# Altium schematic sheet [06] - 3V3 VOLTAGE REGULATOR.SchDoc("[06] - 3V3 VOLTAGE REGULATOR")
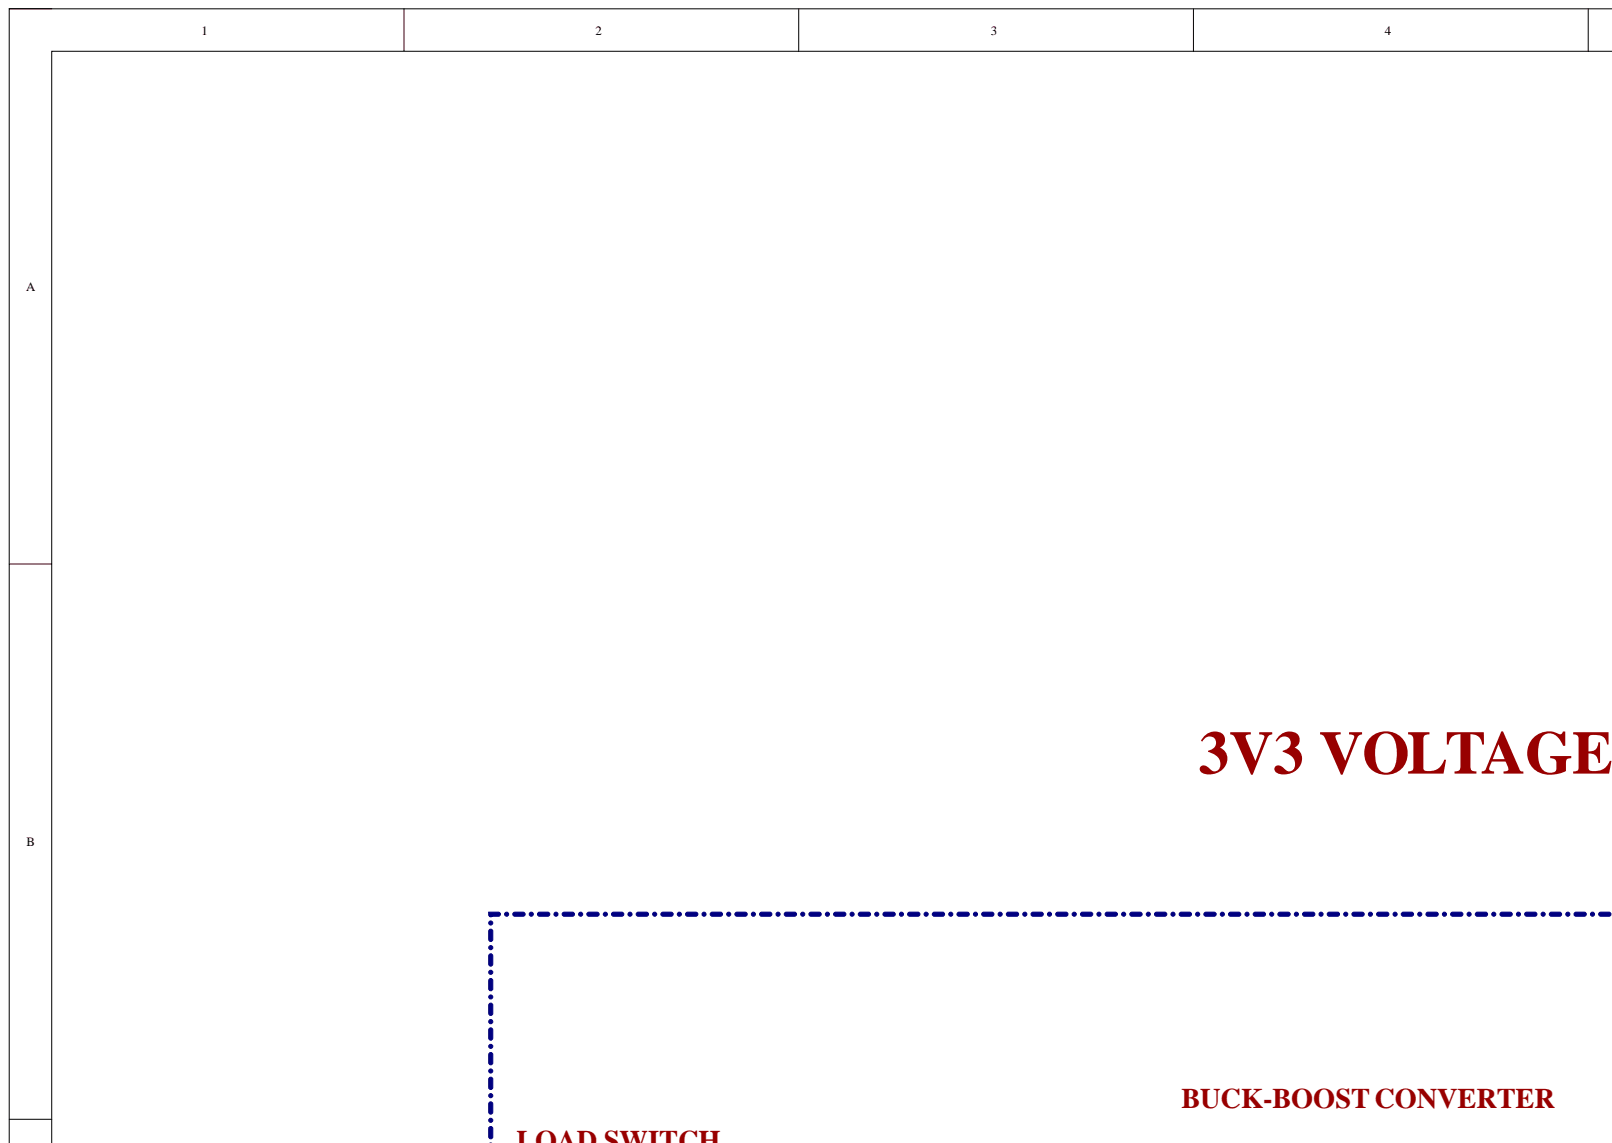
[diagram p0: [06] - 3V3 VOLTAGE REGULATOR.SchDoc, top left]
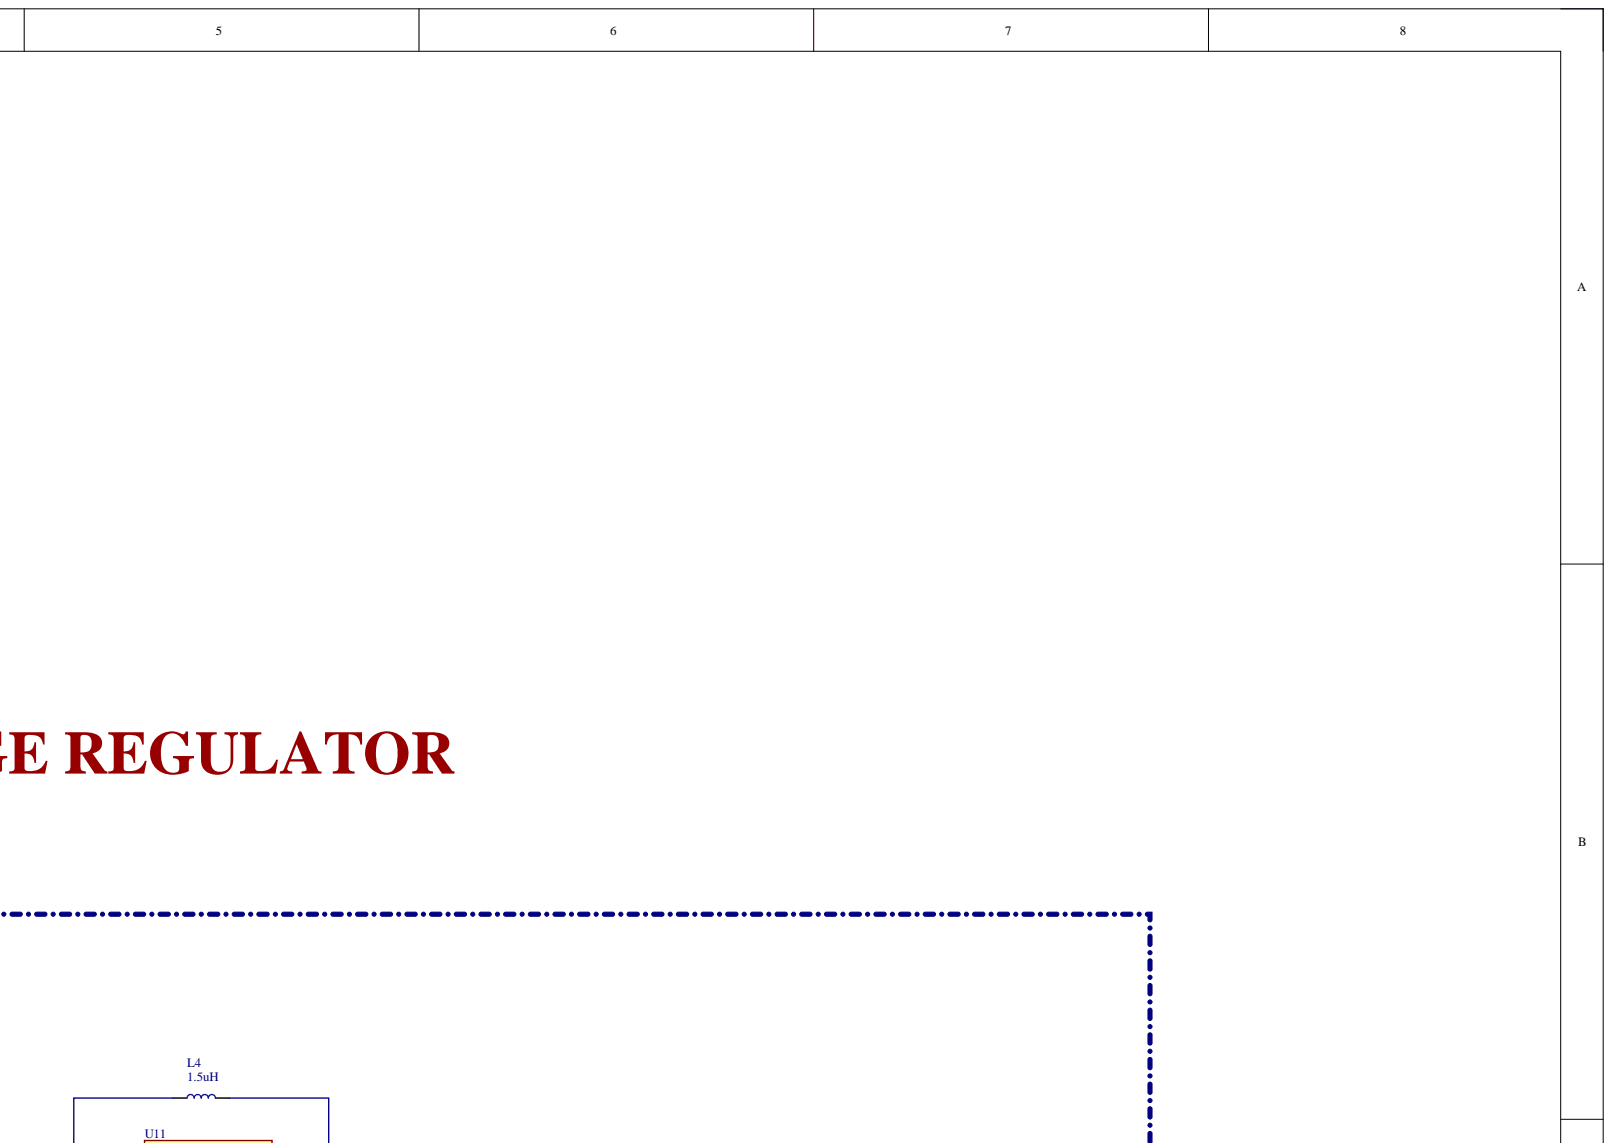
[diagram p1: [06] - 3V3 VOLTAGE REGULATOR.SchDoc, top right]
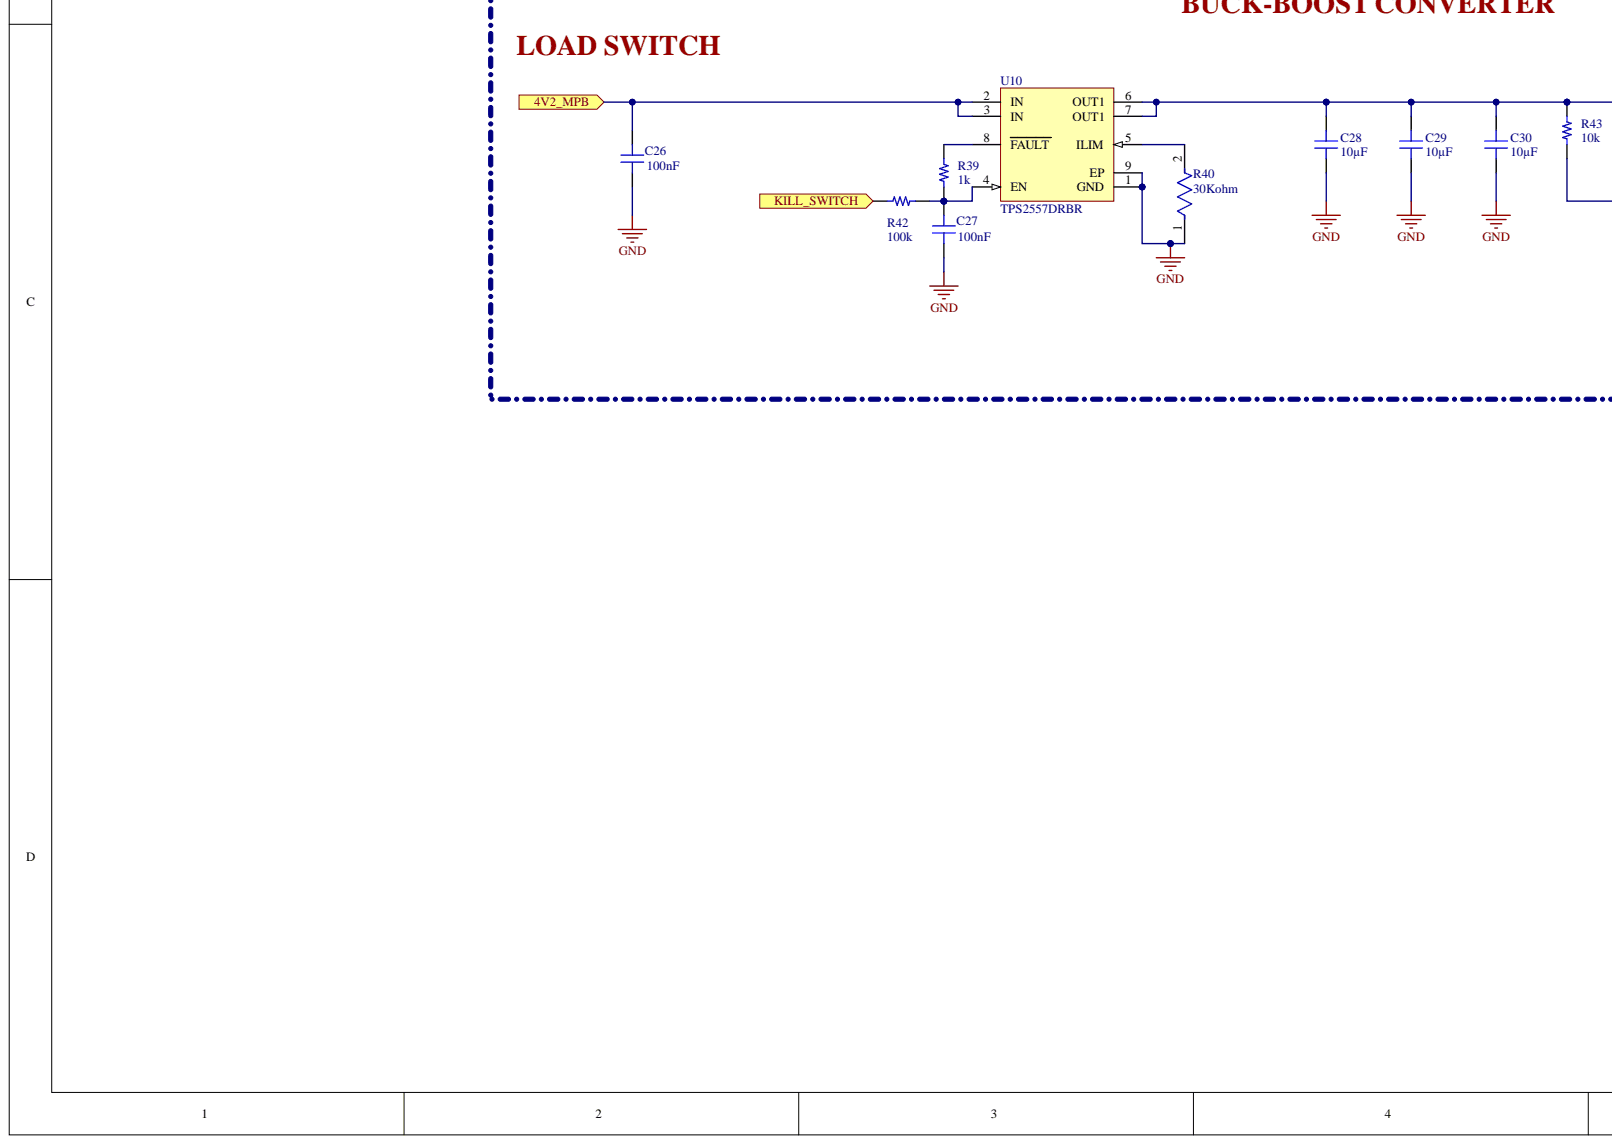
[diagram p2: [06] - 3V3 VOLTAGE REGULATOR.SchDoc, bottom left]
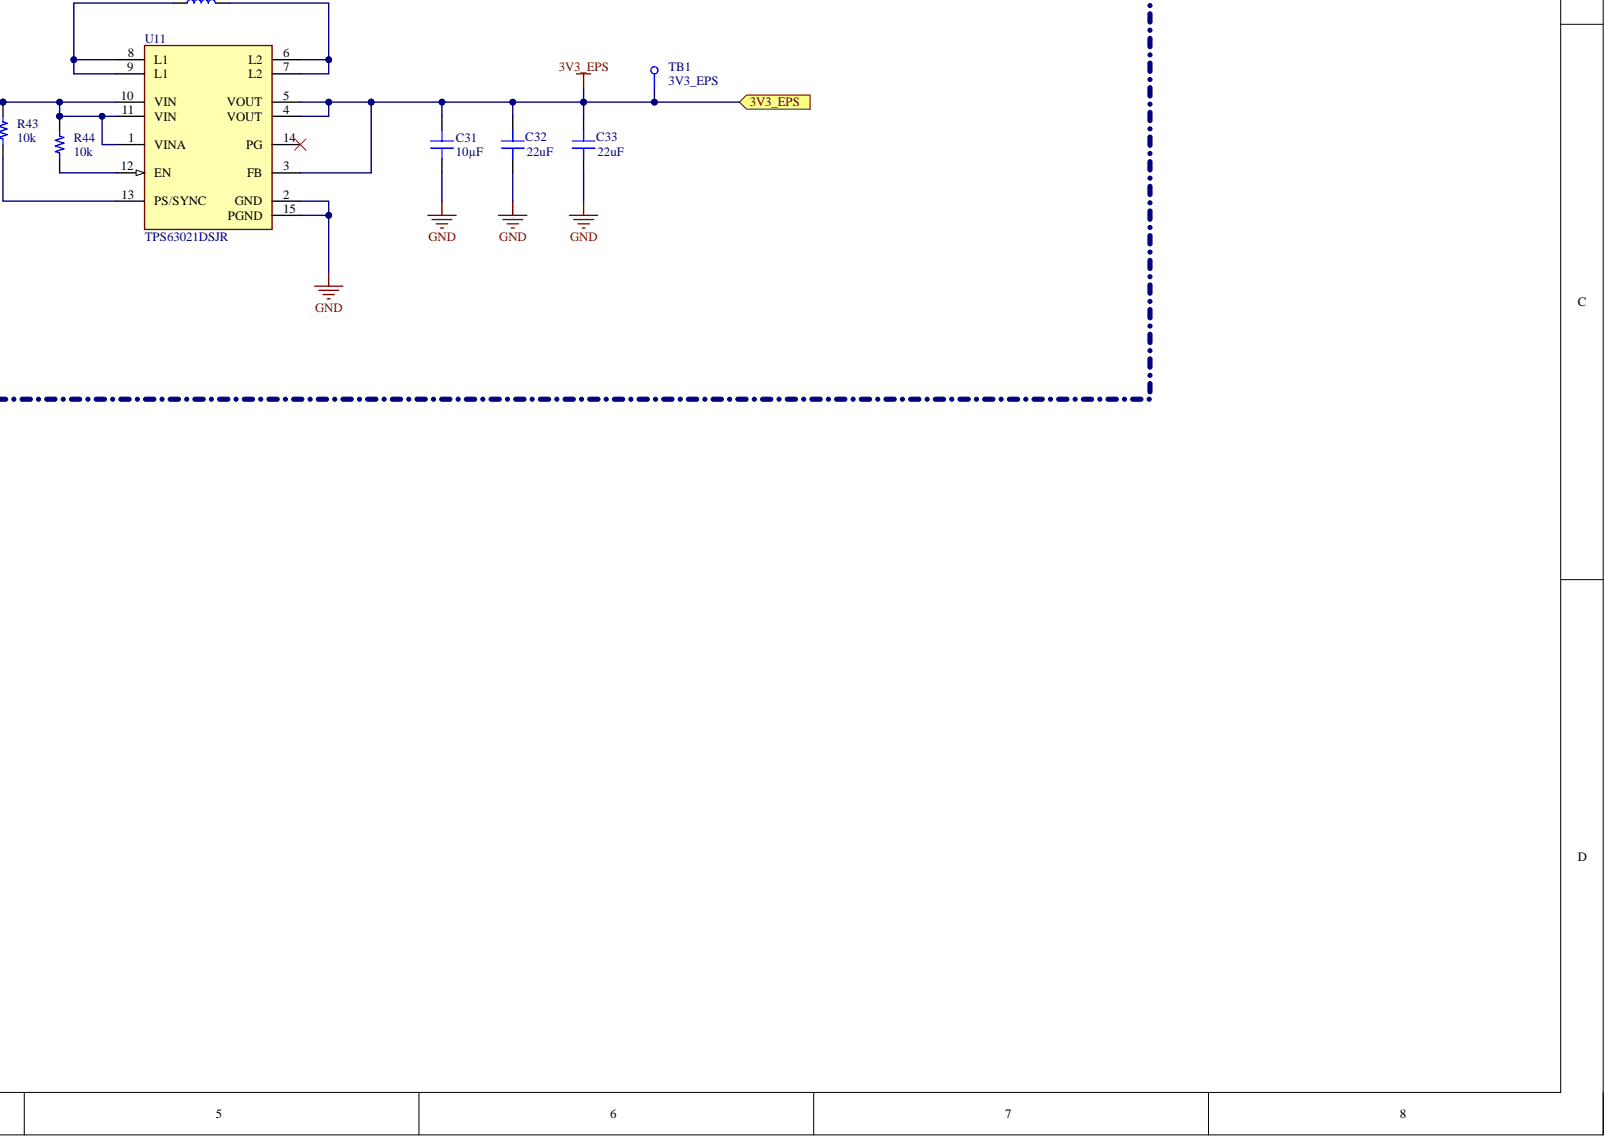
[diagram p3: [06] - 3V3 VOLTAGE REGULATOR.SchDoc, bottom right]

## Parts
C26  Capacitance: 100nF  [CAP 0603/1608]  (hidden comment: C0603C104K8RACTU)
C27  Capacitance: 100nF  [CAP 0603/1608]  (hidden comment: C0603C104K8RACTU)
C28  Capacitance: 10µF  [CAP 0805/2012]  (hidden comment: LMK212BJ106KG-T)
C29  Capacitance: 10µF  [CAP 0805/2012]  (hidden comment: LMK212BJ106KG-T)
C30  Capacitance: 10µF  [CAP 0603/1608]  (hidden comment: CL10A106MQ8NNNC)
C31  Capacitance: 10µF  [CAP 0603/1608]  (hidden comment: CL10A106MQ8NNNC)
C32  Capacitance: 22uF  [CAP 0805/2012]  (hidden comment: CL21A226MQQNNNE)
C33  Capacitance: 22uF  [CAP 0805/2012]  (hidden comment: CL21A226MQQNNNE)
L4  Inductance: 1.5uH  [XFL4020]  (hidden comment: XFL4020-152MEB)
R39  Resistance: 1k  [RES 0603/1608]  (hidden comment: ESR03EZPJ102)
R40  30Kohm  [FP-ESR03-IPC_C]
R42  Resistance: 100k  [RES 0603/1608]  (hidden comment: CR0603-JW-104ELF)
R43  Resistance: 10k  [RES 0805/2012]  (hidden comment: RC0805FR-0710KL)
R44  Resistance: 10k  [RES 0805/2012]  (hidden comment: RC0805FR-0710KL)
TB1  3V3_EPS  [Testpoint]
U10  TPS2557DRBR  [DRB0008B_V]
U11  TPS63021DSJR  [FP-DSJ0014A-MFG]

## Ports
3V3_EPS, 4V2_MPB, KILL_SWITCH

## Nets
GND: C26.1 C27.1 C28.1 C29.1 C30.1 C31.1 C32.1 C33.1 R40.1 U10.1(GND) U10.9(EP) U11.2(GND) U11.15(PGND)
3V3_EPS: C31.2 C32.2 C33.2 TB1.1 U11.3(FB) U11.4(VOUT) U11.5(VOUT) ; port 3V3_EPS
4V2_MPB: C26.2 U10.2(IN) U10.3(IN) ; port 4V2_MPB
KILL_SWITCH: R42.1 ; port KILL_SWITCH
NetC27_2: C27.2 R39.1 R42.2 U10.4(EN)
NetC28_2: C28.2 C29.2 C30.2 R43.2 R44.2 U10.6(OUT1) U10.7(OUT1) U11.1(VINA) U11.10(VIN) U11.11(VIN)
NetL4_1: L4.1 U11.8(L1) U11.9(L1)
NetL4_2: L4.2 U11.6(L2) U11.7(L2)
NetR39_2: R39.2 U10.8(FAULT)
NetR40_2: R40.2 U10.5(ILIM)
NetR43_1: R43.1 U11.13(PS/SYNC)
NetR44_1: R44.1 U11.12(EN)

Not connected: U11 14(PG)

## Library data of the parts
C26 C27 = C0603C104K8RACTU: CAP CER 0.1UF 10V X7R 0603; Manufacturer: KEMET; Dielectric Material: Ceramic; Dissipation Factor: 5%; Tolerance: 10%; Case Code (Imperial): 0603; Case Code (Metric): 1608; Case/Package: 0603; Component Kind: Standard; ComponentLink1Description: http://www.farnell.com/datasheets/2315110.pdf?src-supplier=Farnell; ComponentLink1URL: http://www.farnell.com/datasheets/2315110.pdf?src-supplier=Farnell; ComponentLink2Description: http://www.kemet.com/kemet/web/homepage/kechome.nsf/vapubfiles/KEM_C1002_X7R_SMD.pdf/$file/KEM_C1002_X7R_SMD.pdf?src-supplier=Element14; ComponentLink2URL: http://www.kemet.com/kemet/web/homepage/kechome.nsf/vapubfiles/KEM_C1002_X7R_SMD.pdf/$file/KEM_C1002_X7R_SMD.pdf?src-supplier=Element14; ComponentLink3Description: https://www.verical.com/server-webapp/html/getDatasheet?partID=91529&src-supplier=Verical; ComponentLink3URL: https://www.verical.com/server-webapp/html/getDatasheet?partID=91529&src-supplier=Verical; ComponentLink4Description: http://www.mouser.com/ds/2/212/KEM_C1002_X7R_SMD-1102033.pdf?src-supplier=Mouser; ComponentLink4URL: http://www.mouser.com/ds/2/212/KEM_C1002_X7R_SMD-1102033.pdf?src-supplier=Mouser; ComponentLink5Description: http://www.alliedelec.com/m/d/9bfe7773041ffe9d406e68bc7b377fdd.pdf?src-supplier=Allied+Electronics; ComponentLink5URL: http://www.alliedelec.com/m/d/9bfe7773041ffe9d406e68bc7b377fdd.pdf?src-supplier=Allied+Electronics; ComponentLink6Description: http://www.farnell.com/datasheets/1851736.pdf?src-supplier=Element14; ComponentLink6URL: http://www.farnell.com/datasheets/1851736.pdf?src-supplier=Element14; Contact Plating: Tin,Matte; Depth: 0.8mm; Dielectric: X7R; Height: 0.8mm; Lead Pitch: 0.7mm; Length: 1.6mm; Manufacturer Part Number: C0603C104K8RACTU; Max Operating Temperature: 125°C; Min Operating Temperature: -55°C; Mount: Surface Mount,PCB; Number of Pins: 2; Package Quantity: 1; Packaging: Tape and Reel; Pricing 1: 1=0.15, 10=0.107, 100=0.0504, 500=0.03536, 1000=0.02927 (USD); Radiation Hardening: No; REACH SVHC: No SVHC; Stock 1: 778651; Supplier 1: Digi-Key; Supplier Part Number 1: 399-1095-1-ND; Termination: SMD/SMT; Thickness: 0.034inch; Voltage: 10V; Voltage Rating: 10V; Voltage Rating (DC): 10V; Width: 0.8mm; Library Name: UVG.IntLib
C28 C29 = LMK212BJ106KG-T: CAP CER 10UF 10V X5R 0805; Manufacturer: Taiyo Yuden; PCN Part Number: X5R, X7R Dielectrics 23/Jan/2008; Catalog Drawings: xMK Series_0805_1.25; Featured Product: Multilayer Chip Capacitors; Kits: 587-1797-KIT-ND  - KIT CAP CER HI CAP 0603 & 0805 S; Online Catalog: M Series; Other Names: 587-1300-1; Tolerance: ±10%; Applications: General Purpose; Category: Capacitors; Component Kind: Standard; ComponentLink1Description: https://datasheet.ciiva.com/26872/getdatasheetpartid-638410-26872692.pdf; ComponentLink1URL: https://datasheet.ciiva.com/26872/getdatasheetpartid-638410-26872692.pdf; ComponentLink2Description: https://datasheet.ciiva.com/7975/1497285-7975797.pdf; ComponentLink2URL: https://datasheet.ciiva.com/7975/1497285-7975797.pdf; ComponentLink3Description: https://datasheet.ciiva.com/7975/1497285-7975799.pdf; ComponentLink3URL: https://datasheet.ciiva.com/7975/1497285-7975799.pdf; ComponentLink4Description: https://datasheet.ciiva.com/135/mlcc-all-e-135133.pdf; ComponentLink4URL: https://datasheet.ciiva.com/135/mlcc-all-e-135133.pdf; ComponentLink5Description: https://datasheet.ciiva.com/135/mlcc-all-e-135134.pdf; ComponentLink5URL: https://datasheet.ciiva.com/135/mlcc-all-e-135134.pdf; ComponentLink6Description: https://datasheet.ciiva.com/30259/719lmk212abj106kg-t-30259022.pdf; ComponentLink6URL: https://datasheet.ciiva.com/30259/719lmk212abj106kg-t-30259022.pdf; ComponentLink7Description: https://datasheet.ciiva.com/135/mlcc-all-e-135135.pdf; ComponentLink7URL: https://datasheet.ciiva.com/135/mlcc-all-e-135135.pdf; Family: Ceramic Capacitors; Features: -; Height - Seated (Max): -; Lead Spacing: -; Lead Style: -; Manufacturer Part Number: LMK212BJ106KG-T; Mounting Type: Surface Mount, MLCC; Operating Temperature: -55°C ~ 85°C; Package / Case: 0805 (2012 Metric); Packaging: Cut Tape (CT); Pricing 1: 1=0.14, 10=0.1, 100=0.0468, 500=0.0328, 1000=0.0272 (USD); Ratings: -; Series: M; Size / Dimension: 0.079" L x 0.049" W (2.00mm x 1.25mm); Standard Package: 1; Stock 1: 858762; Supplier 1: Digi-Key; Supplier Part Number 1: 587-1300-1-ND; Temperature Coefficient: X5R; Thickness (Max): 0.053" (1.35mm); Voltage - Rated: 10V; Library Name: UVG.IntLib
C30 C31 = CL10A106MQ8NNNC: CAP CER 10UF 6.3V X5R 0603; Manufacturer: Samsung; Tolerance: 20%; Case Code (Imperial): 0603; Case Code (Metric): 1608; Case/Package: 0603; Component Kind: Standard; ComponentLink1Description: https://datasheet.ciiva.com/25798/cl10a106mq8nnnc-25798320.pdf?src-supplier=Digi-Key; ComponentLink1URL: https://datasheet.ciiva.com/25798/cl10a106mq8nnnc-25798320.pdf?src-supplier=Digi-Key; ComponentLink2Description: https://datasheet.ciiva.com/26838/getdatasheetpartid-357557-26838347.pdf?src-supplier=Verical; ComponentLink2URL: https://datasheet.ciiva.com/26838/getdatasheetpartid-357557-26838347.pdf?src-supplier=Verical; ComponentLink3Description: https://datasheet.ciiva.com/16437/cl05b104kq5nnnc-16437327.pdf?src-supplier=Future+Electronics; ComponentLink3URL: https://datasheet.ciiva.com/16437/cl05b104kq5nnnc-16437327.pdf?src-supplier=Future+Electronics; Contact Plating: Tin; Depth: 0.8mm; Dielectric: X5R; Height: 0.8mm; Length: 1.6mm; Manufacturer Part Number: CL10A106MQ8NNNC; Max Operating Temperature: 85°C; Min Operating Temperature: -55°C; Mount: Surface Mount; Package Quantity: 1; Packaging: Tape and Reel; Pricing 1: 1 = 0.15000, 10 = 0.11000, 100 = 0.05150, 500 = 0.03614, 1000 = 0.02992 (USD); Radiation Hardening: No; RoHS: Yes; Stock 1: 3866590; Supplier 1: Digi-Key; Supplier Part Number 1: 1276-1119-1-ND; Thickness: 0.035inch; Voltage: 6.3V; Voltage Rating: 6.3V; Width: 0.031inch; Library Name: UVG.IntLib
C32 C33 = CL21A226MQQNNNE: CAP CER 22UF 6.3V X5R 0805; Manufacturer: Samsung; Tolerance: 20%; Case Code (Imperial): 0805; Case Code (Metric): 2012; Case/Package: 0805; Component Kind: Standard; ComponentLink1Description: https://datasheet.ciiva.com/25796/cl21a226mqqnnne-25796195.pdf; ComponentLink1URL: https://datasheet.ciiva.com/25796/cl21a226mqqnnne-25796195.pdf; ComponentLink2Description: https://datasheet.ciiva.com/26838/getdatasheetpartid-357607-26838611.pdf; ComponentLink2URL: https://datasheet.ciiva.com/26838/getdatasheetpartid-357607-26838611.pdf; ComponentLink3Description: https://datasheet.ciiva.com/16361/cl21a226mqqnnne-16361189.pdf; ComponentLink3URL: https://datasheet.ciiva.com/16361/cl21a226mqqnnne-16361189.pdf; ComponentLink4Description: https://datasheet.ciiva.com/26787/cl21a226mqqnnne-26787006.pdf; ComponentLink4URL: https://datasheet.ciiva.com/26787/cl21a226mqqnnne-26787006.pdf; Contact Plating: Tin; Depth: 1.25mm; Dielectric: X5R; Height: 1.25mm; Lead Free: Lead Free; Length: 2mm; Manufacturer Part Number: CL21A226MQQNNNE; Max Operating Temperature: 85°C; Min Operating Temperature: -55°C; Mount: Surface Mount; Package Quantity: 2000; Packaging: Tape and Reel; Pricing 1: 1=0.22, 10=0.152, 100=0.0768, 500=0.05256, 1000=0.04649 (USD); Radiation Hardening: No; Stock 1: 20000; Supplier 1: Digi-Key; Supplier Part Number 1: 1276-1100-6-ND; Thickness: 0.055inch; Voltage Rating: 6.3V; Voltage Rating (DC): 6.3V; Width: 0.049inch; Library Name: UVG.IntLib
L4 = XFL4020-152MEB: FIXED IND 1.5UH 9.1A 15.8 MOHM SMD; Manufacturer: Coilcraft; Core Material: Composite; DC Resistance (DCR): 15.8mR; Self Resonant Frequency: 59MHz; Shielding: Shielded; Test Frequency: 100kHz; Tolerance: 20%; Component Kind: Standard; ComponentLink1Description: http://www.mouser.com/ds/2/597/xfl4020-270756.pdf?src-supplier=Mouser; ComponentLink1URL: http://www.mouser.com/ds/2/597/xfl4020-270756.pdf?src-supplier=Mouser; Height: 2.1mm; Lead Free: Contains Lead; Length: 4.3mm; Manufacturer Part Number: XFL4020-152MEB; Max DC Current: 9.1A; Max Operating Temperature: 125°C; Min Operating Temperature: -40°C; Package Quantity: 25; Packaging: Tape and Reel; Pricing 1: 1 = 1.99000, 10 = 1.99000, 50 = 1.99000, 100 = 1.59000, 1000 = 0.88500, 10000 = 0.88500 (USD); RoHS: Yes; Stock 1: 11555; Supplier 1: Mouser; Supplier Part Number 1: 994-XFL4020-152MEB; Termination: SMD/SMT; Width: 4.3mm; Library Name: UVG.IntLib
R39 = ESR03EZPJ102: RES SMD 1K OHM 5% 1/4W 0603; Manufacturer: Rohm; Max Power Dissipation: 250mW; Temperature Coefficient: 200ppm/°C; Case Code (Imperial): 0603; Case Code (Metric): 1608; Case/Package: 0603; Component Kind: Standard; ComponentLink1Description: https://datasheet.ciiva.com/2469/esr-2469603.pdf?src-supplier=Digi-Key; ComponentLink1URL: https://datasheet.ciiva.com/2469/esr-2469603.pdf?src-supplier=Digi-Key; ComponentLink2Description: https://datasheet.ciiva.com/2469/esr-2469604.pdf?src-supplier=Digi-Key; ComponentLink2URL: https://datasheet.ciiva.com/2469/esr-2469604.pdf?src-supplier=Digi-Key; ComponentLink3Description: https://datasheet.ciiva.com/2469/esr-2469605.pdf?src-supplier=Digi-Key; ComponentLink3URL: https://datasheet.ciiva.com/2469/esr-2469605.pdf?src-supplier=Digi-Key; Composition: Thick Film; Features: Automotive AEC-Q200; Height: 0.022inch; Lead Free: Lead Free; Length: 0.063inch; Manufacturer Part Number: ESR03EZPJ102; Max Operating Temperature: 155°C; Min Operating Temperature: -55°C; Number of Terminations: 2; Package Quantity: 1; Packaging: Tape and Reel; Pricing 1: 1 = 0.10000, 10 = 0.08300, 100 = 0.03180, 1000 = 0.01398, 2500 = 0.01207 (USD); RoHS: Yes; Stock 1: 187581; Supplier 1: Digi-Key; Supplier Part Number 1: RHM1.0KDCT-ND; Tolerance: 5%; Width: 0.031inch; Library Name: UVG.IntLib
R40 = CMP-08839-000999-1: 30kΩ ±1% 0.25W 0603 Anti-Surge Chip Resistor AEC-Q200; Max Power Dissipation: 250mW; Resistance: 30kR; Temperature Coefficient: 100ppm/°C; Case/Package: 0603; Component Kind: Standard; Features: Automotive AEC-Q200; Library Name: Altium Content Vault; Max Operating Temperature: 155°C; Min Operating Temperature: -55°C; Number of Terminations: 2; Packaging: Tape and Reel; Resistor Type: Thick Film; RoHS Compliant: Yes; Series: ESR; Tolerance: 1%
R42 = CR0603-JW-104ELF: RES SMD 100K OHM 5% 1/10W 0603; Manufacturer: Bourns; Power Rating: 100mW; Temperature Coefficient: 200ppm/°C; Voltage Rating: 50V; Case Code (Imperial): 0603; Case Code (Metric): 1608; Case/Package: 0603; Component Kind: Standard; ComponentLink1Description: https://datasheet.ciiva.com/22713/691949-22713239.pdf?src-supplier=Element14; ComponentLink1URL: https://datasheet.ciiva.com/22713/691949-22713239.pdf?src-supplier=Element14; ComponentLink2Description: https://datasheet.ciiva.com/23776/1902866-23776391.pdf?src-supplier=Newark; ComponentLink2URL: https://datasheet.ciiva.com/23776/1902866-23776391.pdf?src-supplier=Newark; ComponentLink3Description: https://datasheet.ciiva.com/16766/70154685-16766760.pdf?src-supplier=Allied+Electronics; ComponentLink3URL: https://datasheet.ciiva.com/16766/70154685-16766760.pdf?src-supplier=Allied+Electronics; ComponentLink4Description: https://datasheet.ciiva.com/30229/159chpreztr-30229129.pdf?src-supplier=Onlinecomponents.com; ComponentLink4URL: https://datasheet.ciiva.com/30229/159chpreztr-30229129.pdf?src-supplier=Onlinecomponents.com; ComponentLink5Description: https://datasheet.ciiva.com/16935/chpreztr-16935963.pdf?src-supplier=Avnet+Europe; ComponentLink5URL: https://datasheet.ciiva.com/16935/chpreztr-16935963.pdf?src-supplier=Avnet+Europe; ComponentLink6Description: https://datasheet.ciiva.com/5543/chpreztr-5543676.pdf?src-supplier=Mouser; ComponentLink6URL: https://datasheet.ciiva.com/5543/chpreztr-5543676.pdf?src-supplier=Mouser; ComponentLink7Description: https://datasheet.ciiva.com/9120/chpreztr-9120764.pdf?src-supplier=Avnet; ComponentLink7URL: https://datasheet.ciiva.com/9120/chpreztr-9120764.pdf?src-supplier=Avnet; ComponentLink8Description: https://datasheet.ciiva.com/46383/1791942-46383790.pdf?src-supplier=Element14; ComponentLink8URL: https://datasheet.ciiva.com/46383/1791942-46383790.pdf?src-supplier=Element14; ComponentLink9Description: https://datasheet.ciiva.com/48692/001055501-da-01-en-smd-wid-cr0603-jw-104elf-100k-ohm-1-10w-48692133.pdf?src-supplier=Conrad; ComponentLink9URL: https://datasheet.ciiva.com/48692/001055501-da-01-en-smd-wid-cr0603-jw-104elf-100k-ohm-1-10w-48692133.pdf?src-supplier=Conrad; Composition: Thick Film; Contact Plating: Tin; Depth: 0.8mm; Height: 0.45mm; Length: 1.6mm; Manufacturer Part Number: CR0603-JW-104ELF; Max Operating Temperature: 155°C; Military Standard: Not; Min Operating Temperature: -55°C; Mount: Surface Mount; Number of Pins: 2; Package Quantity: 5000; Packaging: Tape and Reel; Pricing 1: 1 = 0.10000, 10 = 0.01500, 25 = 0.01080, 50 = 0.00840, 100 = 0.00610, 250 = 0.00468, 500 = 0.00374, 1000 = 0.00275 (USD); Radiation Hardening: No; REACH SVHC: No SVHC; RoHS: Yes; Stock 1: 138181; Supplier 1: Digi-Key; Supplier Part Number 1: CR0603-JW-104ELFCT-ND; Termination: SMD/SMT; Thickness: 457um; Tolerance: 5%; Voltage Rating (DC): 50V; Width: 0.8mm; Working Voltage: 50V; Library Name: UVG.IntLib
R43 R44 = RC0805FR-0710KL: RES SMD 10K OHM 1% 1/8W 0805; Manufacturer: Yageo; Power Rating: 125 mW (1/8 W); Temperature Coefficient: 100 PPM / C; Voltage Rating: 150 V; Case Code - in: 0805; Case Code - mm: 2012; Component Kind: Standard; ComponentLink1Description: https://datasheet.ciiva.com/11808/0900766b8106beab-11808151.pdf; ComponentLink1URL: https://datasheet.ciiva.com/11808/0900766b8106beab-11808151.pdf; ComponentLink2Description: https://datasheet.ciiva.com/12669/rc0805-12669688.pdf; ComponentLink2URL: https://datasheet.ciiva.com/12669/rc0805-12669688.pdf; ComponentLink3Description: https://datasheet.ciiva.com/46447/444164-46447563.pdf; ComponentLink3URL: https://datasheet.ciiva.com/46447/444164-46447563.pdf; ComponentLink4Description: https://datasheet.ciiva.com/2456/pyu-r-int-thick-7-2456972.pdf; ComponentLink4URL: https://datasheet.ciiva.com/2456/pyu-r-int-thick-7-2456972.pdf; ComponentLink5Description: https://datasheet.ciiva.com/2456/pyu-r-int-thick-7-2456973.pdf; ComponentLink5URL: https://datasheet.ciiva.com/2456/pyu-r-int-thick-7-2456973.pdf; ComponentLink6Description: https://datasheet.ciiva.com/2456/pyu-r-int-thick-7-2456974.pdf; ComponentLink6URL: https://datasheet.ciiva.com/2456/pyu-r-int-thick-7-2456974.pdf; ComponentLink7Description: https://datasheet.ciiva.com/16747/rc0805-16747087.pdf; ComponentLink7URL: https://datasheet.ciiva.com/16747/rc0805-16747087.pdf; Manufacturer Part Number: RC0805FR-0710KL; Operating Temperature Range: - 55 C to + 155 C; Packaging: Reel; Pricing 1: 1=0.1, 10=0.022, 100=0.0088, 1000=0.004, 2500=0.0034 (USD); Product: Thick Film Resistors General Purpose; Series: RC; Stock 1: 19355771; Supplier 1: Digi-Key; Supplier Part Number 1: 311-10.0KCRCT-ND; Termination Style: SMD/SMT; Tolerance: 1 %; Library Name: UVG.IntLib
TB1 = Testpoint: GENERIC TESTPOINT; Component Kind: Standard; Library Name: UVG.IntLib
U10 = CMP-0337-00220-2: Precision Adjustable Current-Limited Power-Distribution Switch, 0.5 to 5.0 A Current Limit, -40 to 85 degC, 8-pin SON (DRB), Green (RoHS & no Sb/Br); Manufacturer: Texas Instruments; Continuous Current(Max)(A): 5; Current Limit Accuracy @ 1A: +/-7.0% (4100mA min / 4715mA max); Current Limit Topology: Constant-Current; Enable: Active High; Number of Switches: 1; Rating: Catalog; rDS(on) per FET(Typ)(mOhms): 22; Vin(Max)(V): 6.5; Vin(Min)(V): 2.5; Component Kind: Standard; ComponentLink1Description: Datasheet; ComponentLink1URL: http://www.ti.com/general/docs/lit/getliterature.tsp?genericPartNumber=TPS2557&fileType=pdf; Current Limit(A): 0.5 to 5.0; DatasheetVersion: SLVS931A; Eco-Plan: Green (RoHS & no Sb/Br); Library Name: Altium Content Vault; Mounting Technology: Surface Mount; PackageDescription: 8-Pin Plastic Small Outline No-Lead, 3 x 3 x 1 mm Body, 0.65 mm Pitch, Exposed Pad; PackageReference: DRB0008B; PackageVersion: revK, Jun-2012; PartNumber: TPS2557DRBR
U11 = CMP-04918-000102-1: IC REG BCK BST 3.3V 3.5A 14VSON; Manufacturer: Texas Instruments; Max Duty Cycle: 100%; Max Junction Temp: +125°C; Max Supply Voltage: 5.5V; Min Supply Voltage: 1.8V; Nominal Supply Current: 25uA; Number Of Outputs: 1; Output Current: 2A; Output Type: AdjustableProgrammable; Output Voltage: 1.2-5.5V; Standoff Height: 0mm; Switching Frequency: 2400kHz; Switching Topology: Buck-Boost; Ambient Temperature Range High: +85°C; Ambient Temperature Range Low: -40°C; Automotive: No; Category: IC; Component Kind: Standard; ComponentLink2Description: Datasheet; ComponentLink2URL: http://www.ti.com/lit/ds/symlink/tps63021.pdf; Device Class L1: Integrated Circuits (ICs); Device Class L2: Power Management ICs; Device Class L3: Voltage Regulators - Switching; Digikey Description: IC REG BCK BST 3.3V 3.5A 14VSON; Height: 1mm; Lead Free: Yes; Library Name: Altium Content Vault; Manufacturer Part Number: TPS63021DSJR; Mouser Description: Conv DC-DC 1.8V to 5.5V Non-Inv/Inv/Step Up/Step Down Single-Out 3.3V 3A 14-Pin VSON EP T/R; Octopart: https://octopart.com/tps63021dsjr-texas+instruments-17659431; Package: DSJ0014A; RoHS: TRUE; Temperature: -40°C to +85°C; Temperature Range High: +85°C; Temperature Range Low: -40°C
